# Altium schematic sheet Power Management.SchDoc
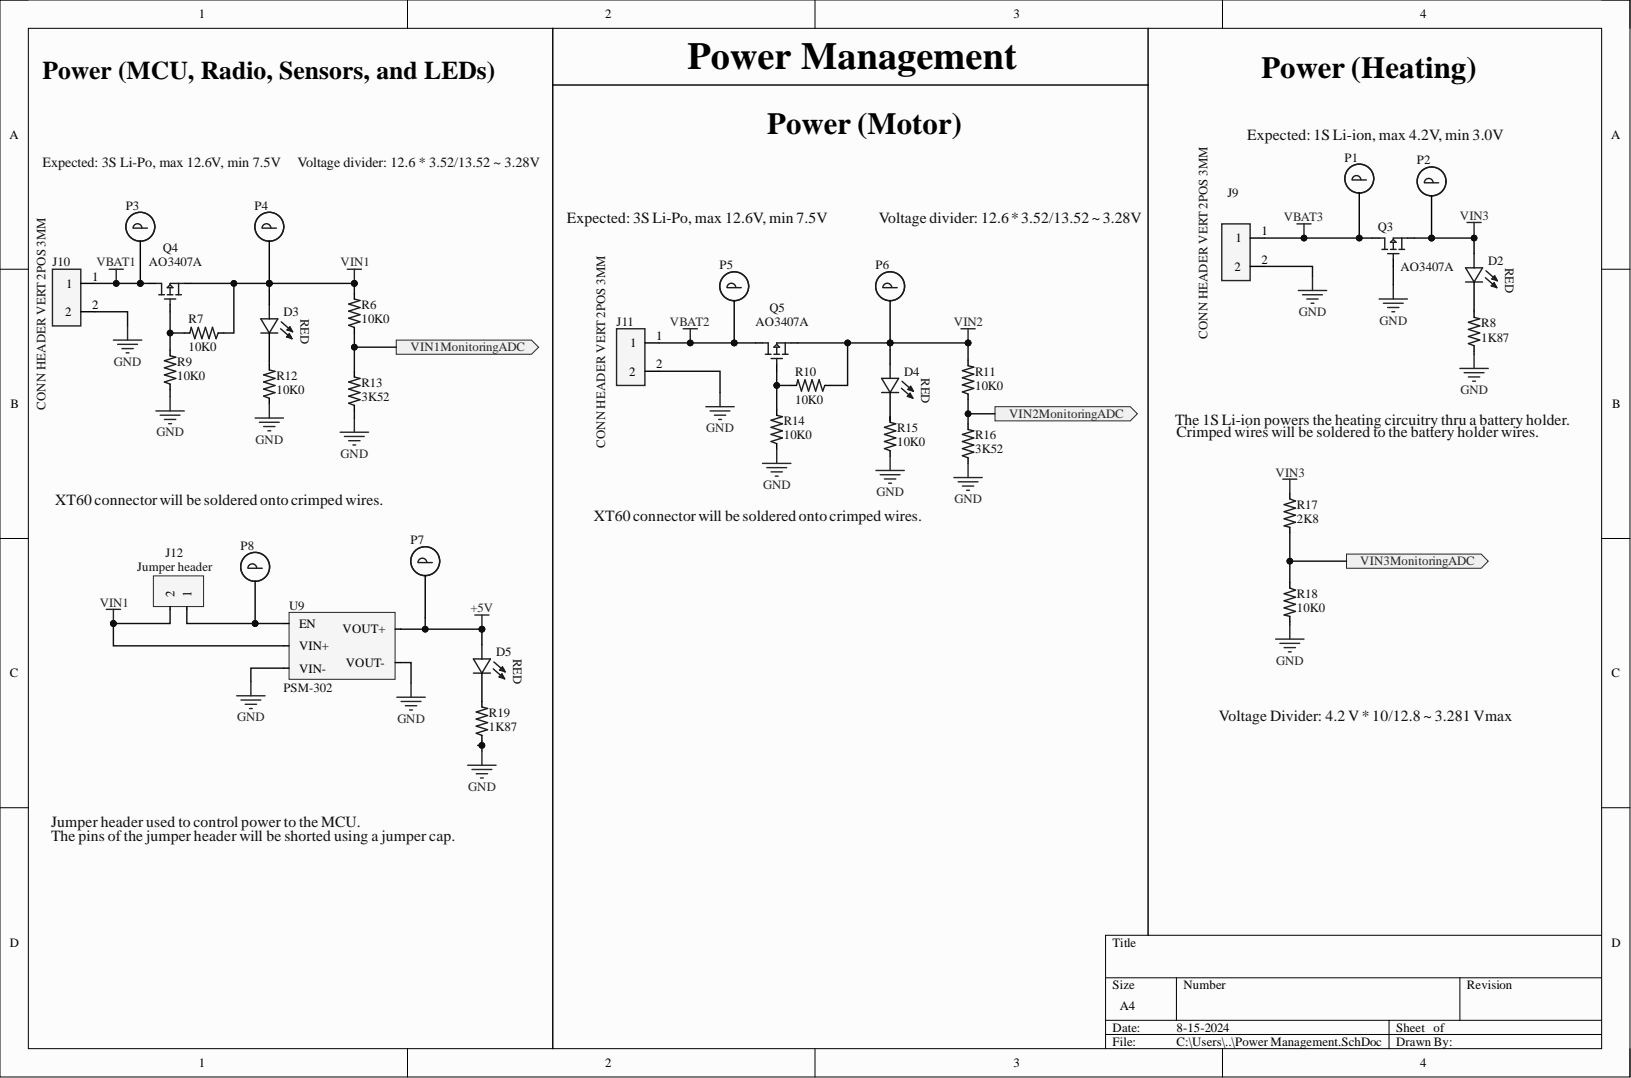
[diagram p0: Power Management.SchDoc]

## Parts
D2  LED 0805  [LED_0805]  (value not drawn)
D3  LED 0805  [LED_0805]  (value not drawn)
D4  LED 0805  [LED_0805]  (value not drawn)
D5  LED 0805  [LED_0805]  (value not drawn)
J9  CONN HEADER VERT 2POS 3MM  [CONN HEADER VERT 2POS 3MM]
J10  CONN HEADER VERT 2POS 3MM  [CONN HEADER VERT 2POS 3MM]
J11  CONN HEADER VERT 2POS 3MM  [CONN HEADER VERT 2POS 3MM]
J12  Jumper header  [2 Pin Male Header]
P1  Probe_5019  [Testpoint]  (value not drawn)
P2  Probe_5019  [Testpoint]  (value not drawn)
P3  Probe_5019  [Testpoint]  (value not drawn)
P4  Probe_5019  [Testpoint]  (value not drawn)
P5  Probe_5019  [Testpoint]  (value not drawn)
P6  Probe_5019  [Testpoint]  (value not drawn)
P7  Probe_5019  [Testpoint]  (value not drawn)
P8  Probe_5019  [Testpoint]  (value not drawn)
Q3  AO3407A  [AO3407A]
Q4  AO3407A  [AO3407A]
Q5  AO3407A  [AO3407A]
R6  10K0  [res 0805]
R7  10K0  [res 0805]
R8  1K87  [res 0805]
R9  10K0  [res 0805]
R10  10K0  [res 0805]
R11  10K0  [res 0805]
R12  10K0  [res 0805]
R13  3K52  [res 0805]
R14  10K0  [res 0805]
R15  10K0  [res 0805]
R16  3K52  [res 0805]
R17  2K8  [res 0805]
R18  10K0  [res 0805]
R19  1K87  [res 0805]
U9  PSM-302  [PSM-302]

## Ports
VIN1MonitoringADC, VIN2MonitoringADC, VIN3MonitoringADC

## Nets
+5V: D5.2 P7.1 U9.5(VOUT+)
GND: J9.2 J10.2 J11.2 Q3.1 R8.1 R9.1 R12.1 R13.1 R14.1 R15.1 R16.1 R18.2 R19.1 U9.3(VIN-) U9.4(VOUT-)
VBAT1: J10.1 P3.1 Q4.3
VBAT2: J11.1 P5.1 Q5.3
VBAT3: J9.1 P1.1 Q3.3
VIN1: D3.2 J12.2 P4.1 Q4.2 R6.2 R7.1 U9.2(VIN+)
VIN2: D4.2 P6.1 Q5.2 R10.1 R11.2
VIN3: D2.2 P2.1 Q3.2 R17.1
VIN1MonitoringADC: R6.1 R13.2 ; port VIN1MonitoringADC
VIN2MonitoringADC: R11.1 R16.2 ; port VIN2MonitoringADC
VIN3MonitoringADC: R17.2 R18.1 ; port VIN3MonitoringADC
NetD2_1: D2.1 R8.2
NetD3_1: D3.1 R12.2
NetD4_1: D4.1 R15.2
NetD5_1: D5.1 R19.2
NetJ12_1: J12.1 P8.1 U9.1(EN)
NetQ4_1: Q4.1 R7.2 R9.2
NetQ5_1: Q5.1 R10.2 R14.2

## Library data of the parts
D2-D5 = LED 0805: Red LED Indication - Discrete 1.7V 0805 (2012 Metric); MP: 7012X1; Availability: Unavailable; Component Kind: Standard; Package: None; Price: None; Library Name: Payload schlib.SchLib
J9-J11 = 0436500228: Rectangular Power Connector, 2 Contact(s), Female, Solder Terminal; Manufacturer: Molex; Manufacturer Part Number: 43650-0228; Manufacturer Series: 43650; Board Mounting Option: PEG; Body Breadth: 0.219inch; Body Depth: 0.39inch; Body Length: 0.38inch; Body/Shell Style: RECEPTACLE; Connector Type: Power,Rectangular; Contact Resistance: 10mR; Contact Style: SQ PIN-SKT; Dielectric Withstanding Voltage (AC): 1.5kV; DIN Conformance: No; Durability: 30Cycles; Insertion Force-Max: 8.0064N; Insulation Resistance: 1GR; Mating Contact Pitch: 0.118inch; Mating Information: MULTIPLE MATING PARTS AVAILABLE; Mounting Option 1: POLARIZED LCK; Mounting Option 2: LOCKING; MP: 0436500200; Number Of Connectors: 1; Number of Contacts: 2; Option: General Purpose; Plating Thickness: 15uin; Polarization Key: POLARIZED HOUSING; Rated Current (Signal): 5A; Reference Standard: UL; Reliability: COMMERCIAL; Withdrawl Force-Min: 3.614N; Availability: Unavailable; Category: Headers and Wire Housings; Component Kind: Standard; ComponentLink1Description: https://datasheet.ciiva.com:443/pdfs/VipMasterIC/IC/MOLE/MOLES07498/MOLES07498-1.pdf?src-supplier=IHS+Markit; ComponentLink1URL: https://datasheet.ciiva.com:443/pdfs/VipMasterIC/IC/MOLE/MOLES07498/MOLES07498-1.pdf?src-supplier=IHS+Markit; ComponentLink2Description: https://datasheet.ciiva.com/13550/0436500228-pcb-headers-148884-13550297.pdf?src-supplier=Mouser; ComponentLink2URL: https://datasheet.ciiva.com/13550/0436500228-pcb-headers-148884-13550297.pdf?src-supplier=Mouser; Contact Finish - Mating: AU; Contact Finish - Termination: TIN/LEAD OVER NICKEL; Contact Gender: Male; Contact Material: Brass; Contact Pattern: RECTANGULAR; Empty Shell: No; Filter Feature: No; Flammability Rating: UL94 V-0; IEC Conformance: No; Insulation Color: Black; Insulation Material: Polymer; Max Operating Temperature: 105°C; MF: Molex; MIL Conformance: No; Min Operating Temperature: -40°C; Mixed Contacts: No; Mounting Style: STRAIGHT; Mounting Technology: Board; Number Of PCB Rows: 1; Number of Rows Loaded: 1; Package: None; PCB Contact Pattern: RECTANGULAR; Price: None; Pricing: 1=0.78, 10=0.537, 50=0.537, 100=0.537, 1000=0.536, 10000=0.473 (USD); Reach SVHC Compliant: Yes; RoHS: Yes; RoHS Compliant: Yes; Shell Material: Polymer; SnapEDA_Link: https://www.snapeda.com/parts/0436500200/Molex/view-part/552556/?ref=snap; Stock: 12783; Supplier: Mouser; Supplier Part Number: 538-43650-0228; Terminal Length: 0.125inch; Terminal Pitch: 2.9972mm; Termination: Solder; Library Name: Payload schlib.SchLib
J12 = 2 Pin Male Header: Board Connector, 2 Contact(s), 1 Row(s), Male, Straight, 0.1 inch Pitch, Solder Terminal, Black Insulator, Receptacle; Manufacturer: TE Connectivity; Manufacturer Part Number: 5-146277-2; Body Breadth: 0.092inch; Body Depth: 0.09inch; Body Length: 0.184inch; Contact Pattern: RECTANGULAR; Contact Style: SQ PIN-SKT; DIN Conformance: No; Number Of Connectors: 1; Number of Contacts: 2; Option: General Purpose; Plating Thickness: 30uin; Reference Standard: UL; Reliability: COMMERCIAL; Body/Shell Style: RECEPTACLE; Category: Connectors; Component Kind: Standard; ComponentLink1Description: https://datasheet.ciiva.com:443/pdfs/VipMasterIC/IC/TYEL/TYEL-L-A0003497673/TYEL-S-A0000657051-1.pdf?src-supplier=IHS+Markit; ComponentLink1URL: https://datasheet.ciiva.com:443/pdfs/VipMasterIC/IC/TYEL/TYEL-L-A0003497673/TYEL-S-A0000657051-1.pdf?src-supplier=IHS+Markit; ComponentLink2Description: https://datasheet.ciiva.com/22660/1537112-22660377.pdf?src-supplier=Element14; ComponentLink2URL: https://datasheet.ciiva.com/22660/1537112-22660377.pdf?src-supplier=Element14; ComponentLink3Description: https://datasheet.ciiva.com/717/eng-cd-146277-h-146277-1-c-dwg-717333.pdf?src-supplier=Digikey; ComponentLink3URL: https://datasheet.ciiva.com/717/eng-cd-146277-h-146277-1-c-dwg-717333.pdf?src-supplier=Digikey; ComponentLink4Description: https://datasheet.ciiva.com/16426/eng-cd-146277-g2-16426389.pdf?src-supplier=Future+Electronics; ComponentLink4URL: https://datasheet.ciiva.com/16426/eng-cd-146277-g2-16426389.pdf?src-supplier=Future+Electronics; ComponentLink5Description: https://datasheet.ciiva.com/30096/146277-30096236.pdf?src-supplier=Onlinecomponents.com; ComponentLink5URL: https://datasheet.ciiva.com/30096/146277-30096236.pdf?src-supplier=Onlinecomponents.com; Connector Type: BOARD CONNECTOR; Contact Finish - Mating: MATTE TIN; Contact Finish - Termination: MATTE TIN; Contact Gender: Male; Filter Feature: No; IEC Conformance: No; Insulation Color: Black; Insulation Material: Polymer; JESD-609 Code: e3; Mating Contact Pitch: 0.1inch; MIL Conformance: No; Mixed Contacts: No; Mounting Style: STRAIGHT; Mounting Technology: Board; Number Of PCB Rows: 1; Number of Rows Loaded: 1; PCB Contact Pattern: RECTANGULAR; Pricing: 1=0.41, 10=0.372, 100=0.3099, 500=0.2634, 1000=0.23087, 5000=0.20608, 10000=0.19176 (USD); Reach SVHC Compliant: Yes; RoHS: Yes; RoHS Compliant: Yes; Stock: 17660; Supplier: Digikey; Supplier Part Number: A121371-ND; Terminal Length: 0.125inch; Terminal Pitch: 2.54mm; Termination: Solder; Library Name: Payload schlib.SchLib
P1-P8 = Probe_5019: Phosphor Bronze Contact Miniature Silver Plated Surface Mount Test Points; Manufacturer: Keystone Electronics; Manufacturer Part Number: 5019; Body Material: Bronze; Category: Connectors; Component Kind: Standard; ComponentLink1Description: https://datasheet.ciiva.com/16318/m60-2p61-16318395.pdf?src-supplier=Future+Electronics; ComponentLink1URL: https://datasheet.ciiva.com/16318/m60-2p61-16318395.pdf?src-supplier=Future+Electronics; ComponentLink2Description: https://datasheet.ciiva.com/16885/70182998-16885945.pdf?src-supplier=Allied+Electronics; ComponentLink2URL: https://datasheet.ciiva.com/16885/70182998-16885945.pdf?src-supplier=Allied+Electronics; ComponentLink3Description: https://datasheet.ciiva.com/14848/m60-2p61-14848437.pdf?src-supplier=Digi-Key; ComponentLink3URL: https://datasheet.ciiva.com/14848/m60-2p61-14848437.pdf?src-supplier=Digi-Key; ComponentLink4Description: https://datasheet.ciiva.com/14848/m60-2p61-14848438.pdf?src-supplier=Digi-Key; ComponentLink4URL: https://datasheet.ciiva.com/14848/m60-2p61-14848438.pdf?src-supplier=Digi-Key; ComponentLink5Description: https://datasheet.ciiva.com/14848/m60-2p61-14848439.pdf?src-supplier=Digi-Key; ComponentLink5URL: https://datasheet.ciiva.com/14848/m60-2p61-14848439.pdf?src-supplier=Digi-Key; Contact Material: Bronze; Contact Plating: Silver; Height: 0.09inch; Lead Free: Lead Free; Material: Bronze; Mount: Surface Mount,PCB; Number of Pins: 1; Package Quantity: 1; Packaging: Tape and Reel; Plating: Silver; Pricing 1: 1=0.12300, 1000=0.12300, 2000=0.11700, 4000=0.11300, 6000=0.11000 (USD); Reach SVHC Compliant: Yes; RoHS: Yes; RoHS Compliant: Yes; Series: 5019; Stock 1: 148000; Supplier 1: Newark; Supplier Part Number 1: 06W4636; Termination: SMD/SMT; Library Name: Payload schlib.SchLib
Q3-Q5 = AO3407A: Small Signal Field-Effect Transistor, 4.3A I(D), 30V, 1-Element, P-Channel, Silicon, Metal-oxide Semiconductor FET; Manufacturer: Alpha & Omega Semiconductor; Drain-source On Resistance-Max: 48mR; Feedback Cap-Max (Crss): 90pF; Number of Elements: 1; Operating Mode: ENHANCEMENT MODE; Package Body Material: Plastic; Power Dissipation-Max (Abs): 1.4W; Qualification Status: Not Qualified; Transistor Application: SWITCHING; Category: MOSFETs; Component Kind: Standard; ComponentLink1Description: https://datasheet.ciiva.com:443/pdfs/VipMasterIC/IC/ALOM/ALOMS01464/ALOMS01464-1.pdf?src-supplier=IHS+Markit; ComponentLink1URL: https://datasheet.ciiva.com:443/pdfs/VipMasterIC/IC/ALOM/ALOMS01464/ALOMS01464-1.pdf?src-supplier=IHS+Markit; ComponentLink2Description: https://datasheet.ciiva.com/940/ao3407a-940745.pdf?src-supplier=Digikey; ComponentLink2URL: https://datasheet.ciiva.com/940/ao3407a-940745.pdf?src-supplier=Digikey; ComponentLink3Description: https://datasheet.ciiva.com/940/ao3407a-940747.pdf?src-supplier=Digikey; ComponentLink3URL: https://datasheet.ciiva.com/940/ao3407a-940747.pdf?src-supplier=Digikey; ComponentLink4Description: https://datasheet.ciiva.com/18057/getdatasheetpartid-64436-18057110.pdf?src-supplier=Verical; ComponentLink4URL: https://datasheet.ciiva.com/18057/getdatasheetpartid-64436-18057110.pdf?src-supplier=Verical; Drain Current-Max (Abs) (ID): 4.3A; Drain Current-Max (ID): 4.3A; DS Breakdown Voltage-Min: 30V; FET Technology: METAL-OXIDE SEMICONDUCTOR; JESD-30 Code: R-PDSO-G3; JESD-609 Code: e3; Manufacturer Part Number: AO3407A; Max Operating Temperature: 150°C; Moisture Sensitivity Level: 1; Package Shape: Rectangular; Package Style: SMALL OUTLINEMeter; Pins: 3; Polarity/Channel Type: P-CHANNEL; Pricing: 1=0.31, 10=0.22, 100=0.1112, 500=0.09854, 1000=0.07668 (USD); Reach SVHC Compliant: Yes; RoHS: Yes; RoHS Compliant: Yes; Stock: 856157; Supplier: Digikey; Supplier Part Number: 785-1006-1-ND; Surface Mount: Yes; Terminal Finish: Tin; Terminal Form: Gull Wing; Terminal Position: DUAL; Transistor Element Material: SILICON; Library Name: Payload schlib.SchLib
R6-R19 = Resistor 0805: MF: TE Connectivity; MP: 2-2176053-2; Power_Rating: .25 W; Resistance: .39; Tolerance: 1%; Availability: Unavailable; Component Kind: Standard; EU_RoHS_Compliance: Compliant with Exemptions; Package: 0805; Price: None; SnapEDA_Link: https://www.snapeda.com/parts/2-2176053-2/TE%20Connectivity/view-part/2214511/?ref=snap; Library Name: Payload schlib.SchLib
U9 = PSM-302: 3-15VDC to 5VDC Step Down Regulator/Converter Module @ 600mA; Component Kind: Standard; Library Name: Payload schlib.SchLib
